# Altium schematic sheet 08_CoreBoard.SchDoc(08_CoreBoard)
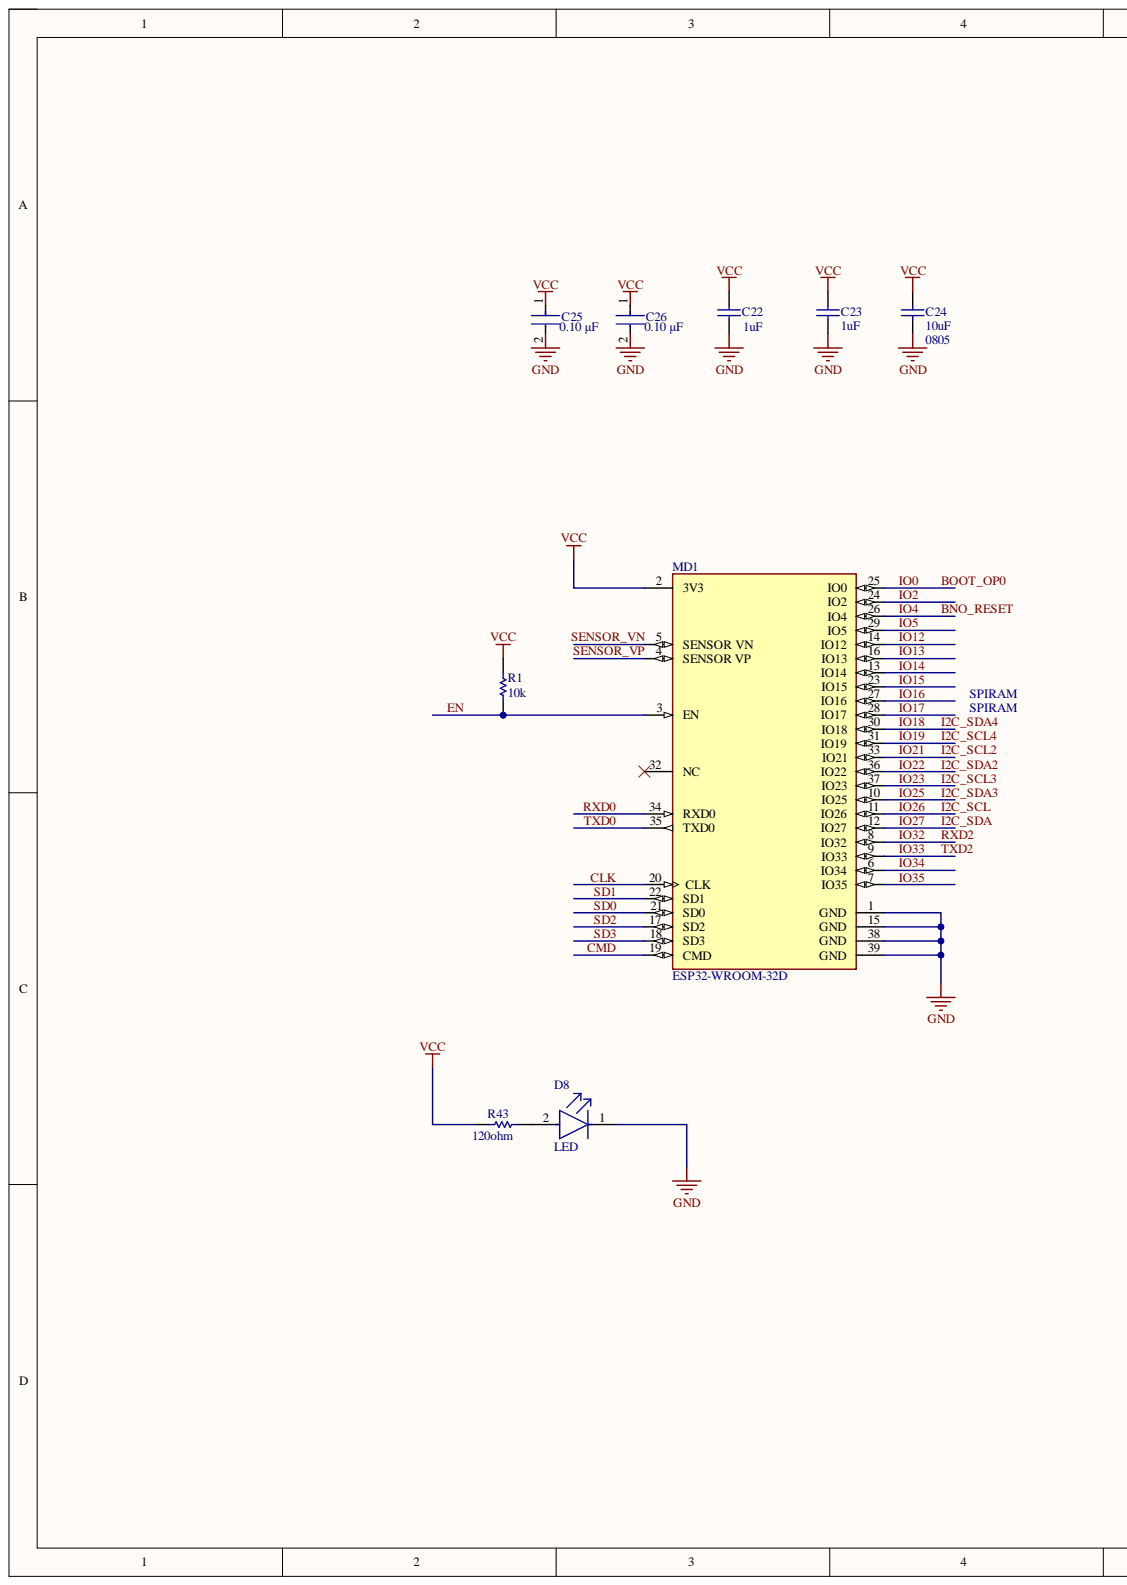
[diagram p0: 08_CoreBoard.SchDoc, left]
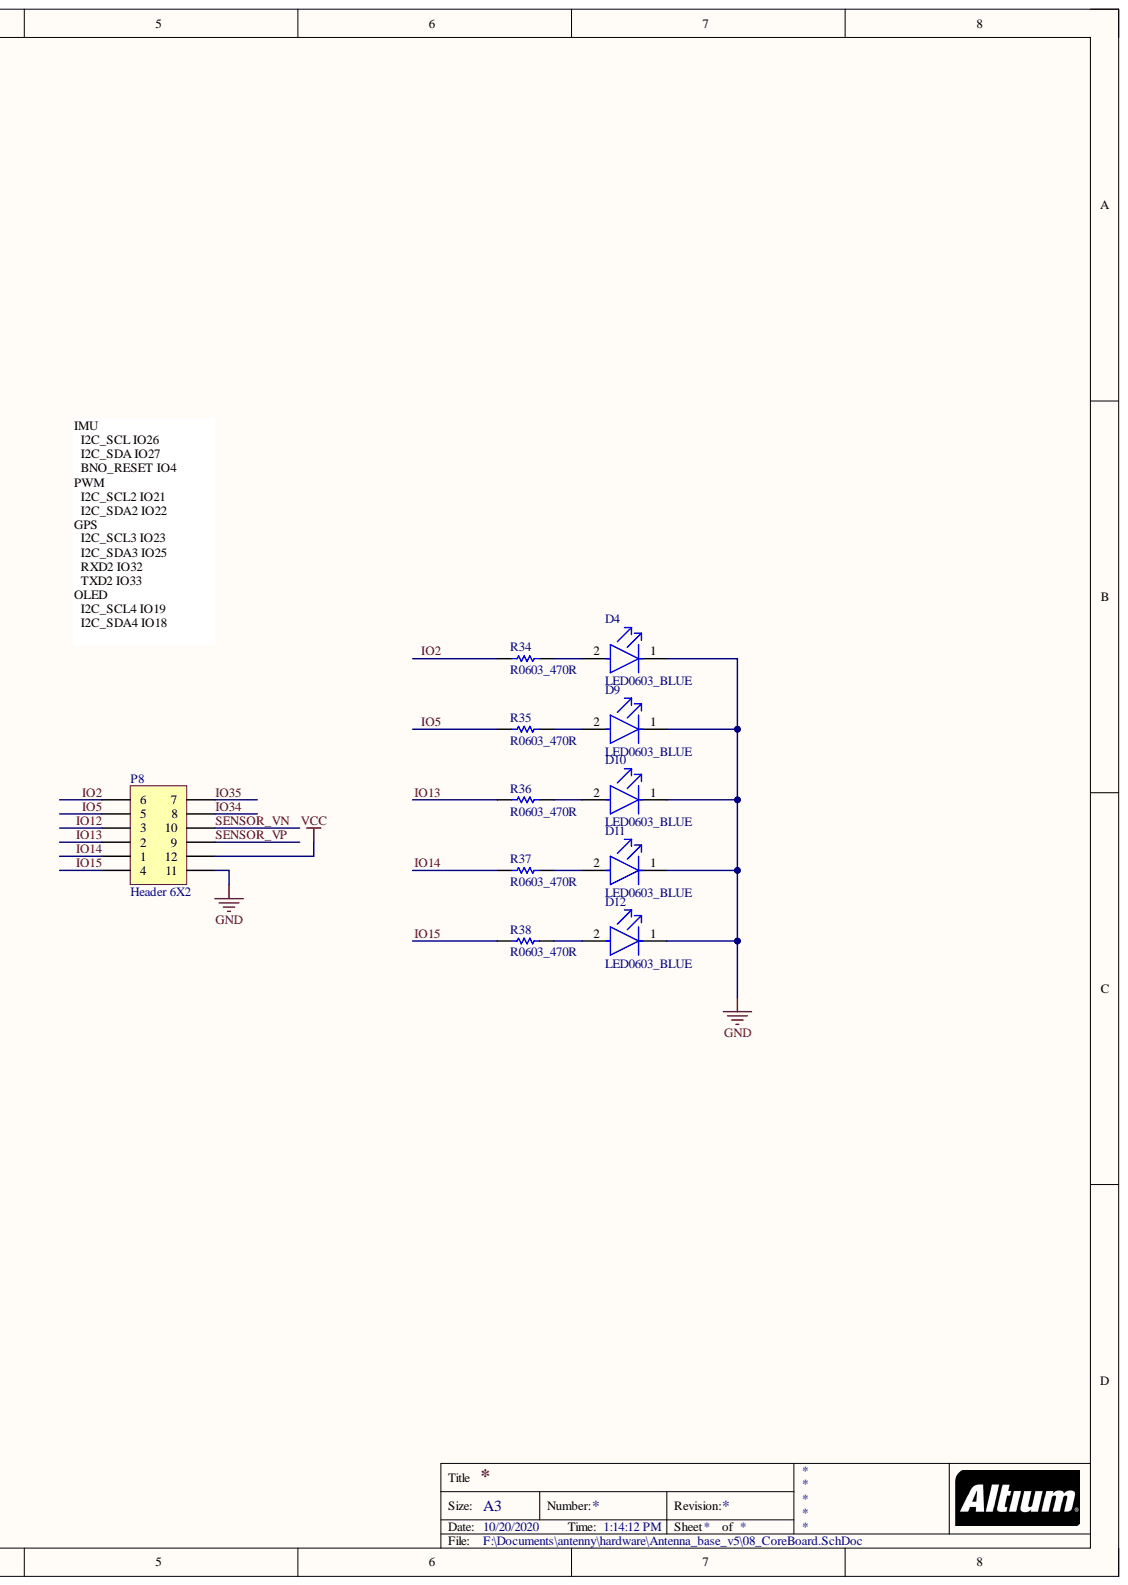
[diagram p1: 08_CoreBoard.SchDoc, right]

## Parts
C22  value 1uF  [CAPC1608X87X35LL15T15]  (hidden comment: C0603_1UF)
C23  value 1uF  [CAPC1608X87X35LL15T15]  (hidden comment: C0603_1UF)
C24  value 10uF  Package: 0805  [CAPC2013X135X50LL10T20]  (hidden comment: C0805_10UF)
C25  value 0.10 μF  Capacitance: 0.10 μF  [FP-C0603C-CF-MFG]  (hidden comment: C0603_0.1UF)
C26  value 0.10 μF  Capacitance: 0.10 μF  [FP-C0603C-CF-MFG]  (hidden comment: C0603_0.1UF)
D4  LED0603_BLUE  [FP-LTST-C191TBKT-MFG]
D8  LED  [FP-LTST-C190GKT-MFG]
D9  LED0603_BLUE  [FP-LTST-C191TBKT-MFG]
D10  LED0603_BLUE  [FP-LTST-C191TBKT-MFG]
D11  LED0603_BLUE  [FP-LTST-C191TBKT-MFG]
D12  LED0603_BLUE  [FP-LTST-C191TBKT-MFG]
MD1  ESP32-WROOM-32D  [FP-ESP32-WROOM-32D-MFG]
P8  Header 6X2  [HDR2X6]
R1  value 10k  [RESC1609X55X30LL15T15]  (hidden comment: R0603_10K)
R34  R0603_470R  [RESC1608X55X30NL15T15]
R35  R0603_470R  [RESC1608X55X30NL15T15]
R36  R0603_470R  [RESC1608X55X30NL15T15]
R37  R0603_470R  [RESC1608X55X30NL15T15]
R38  R0603_470R  [RESC1608X55X30NL15T15]
R43  value 120ohm  [RESC1608X55X30NL20T20]  (hidden comment: R0603_120R)

## Nets
GND: C22.1 C23.1 C24.1 C25.2 C26.2 D4.1 D8.1 D9.1 D10.1 D11.1 D12.1 MD1.1(GND) MD1.15(GND) MD1.38(GND) MD1.39(GND) P8.11
VCC: C22.2 C23.2 C24.2 C25.1 C26.1 MD1.2(3V3) P8.12 R1.1 R43.1
BNO_RESET: MD1.26(IO4)
BOOT_OP0: MD1.25(IO0)
CLK: MD1.20(CLK)
CMD: MD1.19(CMD)
EN: MD1.3(EN) R1.2
I2C_SCL: MD1.11(IO26)
I2C_SCL2: MD1.33(IO21)
I2C_SCL3: MD1.37(IO23)
I2C_SCL4: MD1.31(IO19)
I2C_SDA: MD1.12(IO27)
I2C_SDA2: MD1.36(IO22)
I2C_SDA3: MD1.10(IO25)
I2C_SDA4: MD1.30(IO18)
IO2: MD1.24(IO2) P8.6 R34.1
IO5: MD1.29(IO5) P8.5 R35.1
IO12: MD1.14(IO12) P8.3
IO13: MD1.16(IO13) P8.2 R36.1
IO14: MD1.13(IO14) P8.1 R37.1
IO15: MD1.23(IO15) P8.4 R38.1
IO16: MD1.27(IO16)
IO17: MD1.28(IO17)
IO32: MD1.8(IO32)
IO33: MD1.9(IO33)
IO34: MD1.6(IO34) P8.8
IO35: MD1.7(IO35) P8.7
RXD0: MD1.34(RXD0)
SD0: MD1.21(SD0)
SD1: MD1.22(SD1)
SD2: MD1.17(SD2)
SD3: MD1.18(SD3)
SENSOR_VN: MD1.5(SENSOR_VN) P8.10
SENSOR_VP: MD1.4(SENSOR_VP) P8.9
TXD0: MD1.35(TXD0)
NetD4_2: D4.2 R34.2
NetD8_2: D8.2 R43.2
NetD9_2: D9.2 R35.2
NetD10_2: D10.2 R36.2
NetD11_2: D11.2 R37.2
NetD12_2: D12.2 R38.2

Not connected: MD1 32(NC)

## Library data of the parts
C22 C23 = CMP-2006-03318-1: Manufacturer: Kemet; Part Number: C0603C101J1GACTU; Component Kind: Standard; Library Name: Altium Content Vault
C24 = CMP-2007-03453-1: Manufacturer: Murata; Part Number: GRM21BR61C106KE15L; Component Kind: Standard; Library Name: Altium Content Vault
C25 C26 = CMP-03020-016578-1: CAP CER 0.1UF 16V X7R 0603; Manufacturer: KEMET; Manufacturer Part Number: C0603C104M4RAC7411; Automotive Grade: Grade 1; Material: Ceramic; Temperature Coefficient: 30ppm/°C; Tolerance: 0.2; Automotive: Yes; Category: Cap; Component Kind: Standard; ComponentLink2Description: Datasheet; ComponentLink2URL: https://api.kemet.com/component-edge/download/datasheet/C0603C100J5GACAUTO.pdf; Device Class L1: Passive Components; Device Class L2: Capacitors; Device Class L3: Ceramic Capacitors; Digikey Description: CAP CER 0.1UF 16V X7R 0603; Height: 0.87mm; Lead Free: Yes; Library Name: Altium Content Vault; Mouser Description: Multilayer Ceramic Capacitors MLCC - SMD/SMT 16volts 0.1uF X7R 20%; Octopart: https://octopart.com/c0603c104m4rac7411-kemet-9138805; Package: C0603C-CF; RoHS: TRUE; Temperature: -55°C to +125°C; Temperature Characteristic: X7R; Temperature Range High: +125°C; Temperature Range Low: -55°C; Voltage Rating: 16 V
D4 D9-D12 = CMP-22017-000008-1: LED BLUE CLEAR CHIP SMD; Dominant Wavelength: 475nm; Forward Current: 20mA; Forward Voltage: 3.8V; Lens Color: Colorless; Lens Style: Diffused; Luminous Intensity: 180mcd; Max Power Dissipation: 75mW; Number of Elements: 1; Power Dissipation: 76mW; Reverse Voltage: 5V; Viewing Angle: 130°; Wavelength: 468nm; Case Code (Imperial): 0603; Case Code (Metric): 1608; Case/Package: 0603; Color: Blue; Component Kind: Standard; Depth: 1.6mm; Height: 0.55mm; Illumination Color: Blue; Lead Free: Lead Free; Length: 0.8mm; Lens Transparency: Clear; Library Name: Altium Content Vault; Max Operating Temperature: 80°C; Min Operating Temperature: -20°C; Mount: Surface Mount; Number of LEDs: 1; Number of Pins: 2; Package Quantity: 1; Packaging: Tape and Reel; Radiation Hardening: No; Test Current: 20mA; Width: 0.8mm
D8 = CMP-22017-000005-1: LED GREEN CLEAR CHIP SMD; Dominant Wavelength: 569nm; Forward Current: 30mA; Forward Voltage: 2.1V; Lens Color: Colorless; Luminous Intensity: 6mcd; Number of Elements: 1; Power Dissipation: 100mW; Reverse Voltage: 5V; Test Current: 10mA; Viewing Angle: 130°; Voltage: 2V; Wavelength: 565nm; Case Code (Imperial): 0603; Case Code (Metric): 1608; Case/Package: 0603; Color: Green; Component Kind: Standard; Depth: 0.8mm; Height: 0.8mm; Illumination Color: Green; Lead Free: Lead Free; Length: 1.6mm; Lens Style: Clear; Lens Transparency: Clear; Library Name: Altium Content Vault; Max Operating Temperature: 85°C; Min Operating Temperature: -55°C; Mount: Surface Mount; Number of LEDs: 1; Number of Pins: 2; Package Quantity: 1; Packaging: Tape and Reel; Power Rating: 100mW; Radiation Hardening: No; Width: 0.8mm
MD1 = CMP-194065-000003-1: WIFI MODULE 32MBITS SPI FLASH; Manufacturer: Espressif Systems; Bandwidth: 72MHz; Data Rate: 150Mbits/s; Interface: I2C,SPI,UART,Ethernet,I2S,Other; Max Frequency: 2484MHz; Max Supply Voltage: 3.6V; Min Supply Voltage: 3V; Nominal Supply Current: 500mA; Number Of Adc Channels: 16; Number Of Gpio: 32; Sensitivity: -98dBm; Automotive: No; Category: UNK; Component Kind: Standard; ComponentLink2Description: Datasheet; ComponentLink2URL: https://www.espressif.com/sites/default/files/documentation/esp32-wroom-32d_esp32-wroom-32u_datasheet_en.pdf; Device Class L1: Integrated Circuits (ICs); Device Class L2: RF Semiconductors and Devices; Device Class L3: Transceivers; Digikey Description: WIFI MODULE 32MBITS SPI FLASH; Height: 3.2mm; Lead Free: Yes; Manufacturer Part Number: ESP32-WROOM-32D; Mouser Description: Wi-Fi/BT BLE Module ESP32-D0WD, SPI Flash, UART, P; Octopart: https://octopart.com/esp32-wroom-32d-espressif+systems-91040050; Package: ESP32-WROOM-32D; RoHS: TRUE; Temperature: -40°C to +85°C; Temperature Range High: +85°C; Temperature Range Low: -40°C; Library Name: Finger_module.IntLib
P8 = Header 6X2: Header, 6-Pin, Dual row; Component Kind: Standard; LatestRevisionDate: 17-Jul-2002; LatestRevisionNote: Re-released for DXP Platform.; Library Name: Miscellaneous Connectors.IntLib; Signal Integrity: Connector; Publisher: Altium Limited
R1 = CMP-2000-07452-1: Chip Resistor, 10 KOhm, +/- 1%, 0.1 W, -55 to 155 degC, 0603 (1608 Metric), RoHS, Tape and Reel; Manufacturer: Panasonic; Case\/Package: 0603; Max Power Dissipation: 100mW; Resistance: 10KOhm; Temperature Coefficient: 100ppm\/degreesC; Voltage Rating: 75V; Case Code (Imperial): 0603; Case Code (Metric): 1608; Component Kind: Standard; Composition: ThickFilm; Contact Plating: Tin; Datasheet URL: https://industrial.panasonic.com/cdbs/www-data/pdf/RDA0000/AOA0000C304.pdf; Datasheet Version: 02/2017; Depth: 0.8mm; Height: 0.45mm; Lead Free: LeadFree; Length: 1.6mm; Library Name: Altium Content Vault; Manufacturer URL: http://www.panasonic.com/; Max Operating Temperature: 155degC; Military Standard: Not; Min Operating Temperature: -55degC; Mount: SurfaceMount; Mounting Technology: SurfaceMount; Number of Pins: 2; Number of Terminations: 2; Package Description: 2-Pin Surface Mount Device, Body 1.6 x 0.85 mm; Package Quantity: 1; Package Reference: 603; Packaging: TapeandReel; Power Rating: 100mW; Radiation Hardening: No; REACH SVHC: NoSVHC; RoHSCompliant: true; Termination: SMD/SMT; Tolerance: 1%; Voltage Rating (DC): 75V; Width: 0.031inch
R34-R38 = CMP-2000-00795-1: Manufacturer: Panasonic; Part Number: ERJ-3GEYJ471V; Value: 470; Component Kind: Standard; Library Name: Altium Content Vault
R43 = CMP-2000-00896-1: Manufacturer: Panasonic; Part Number: ERA-3AEB121V; Component Kind: Standard; Library Name: Altium Content Vault
